# Altium schematic sheet User_Interface.SchDoc("User Interface")
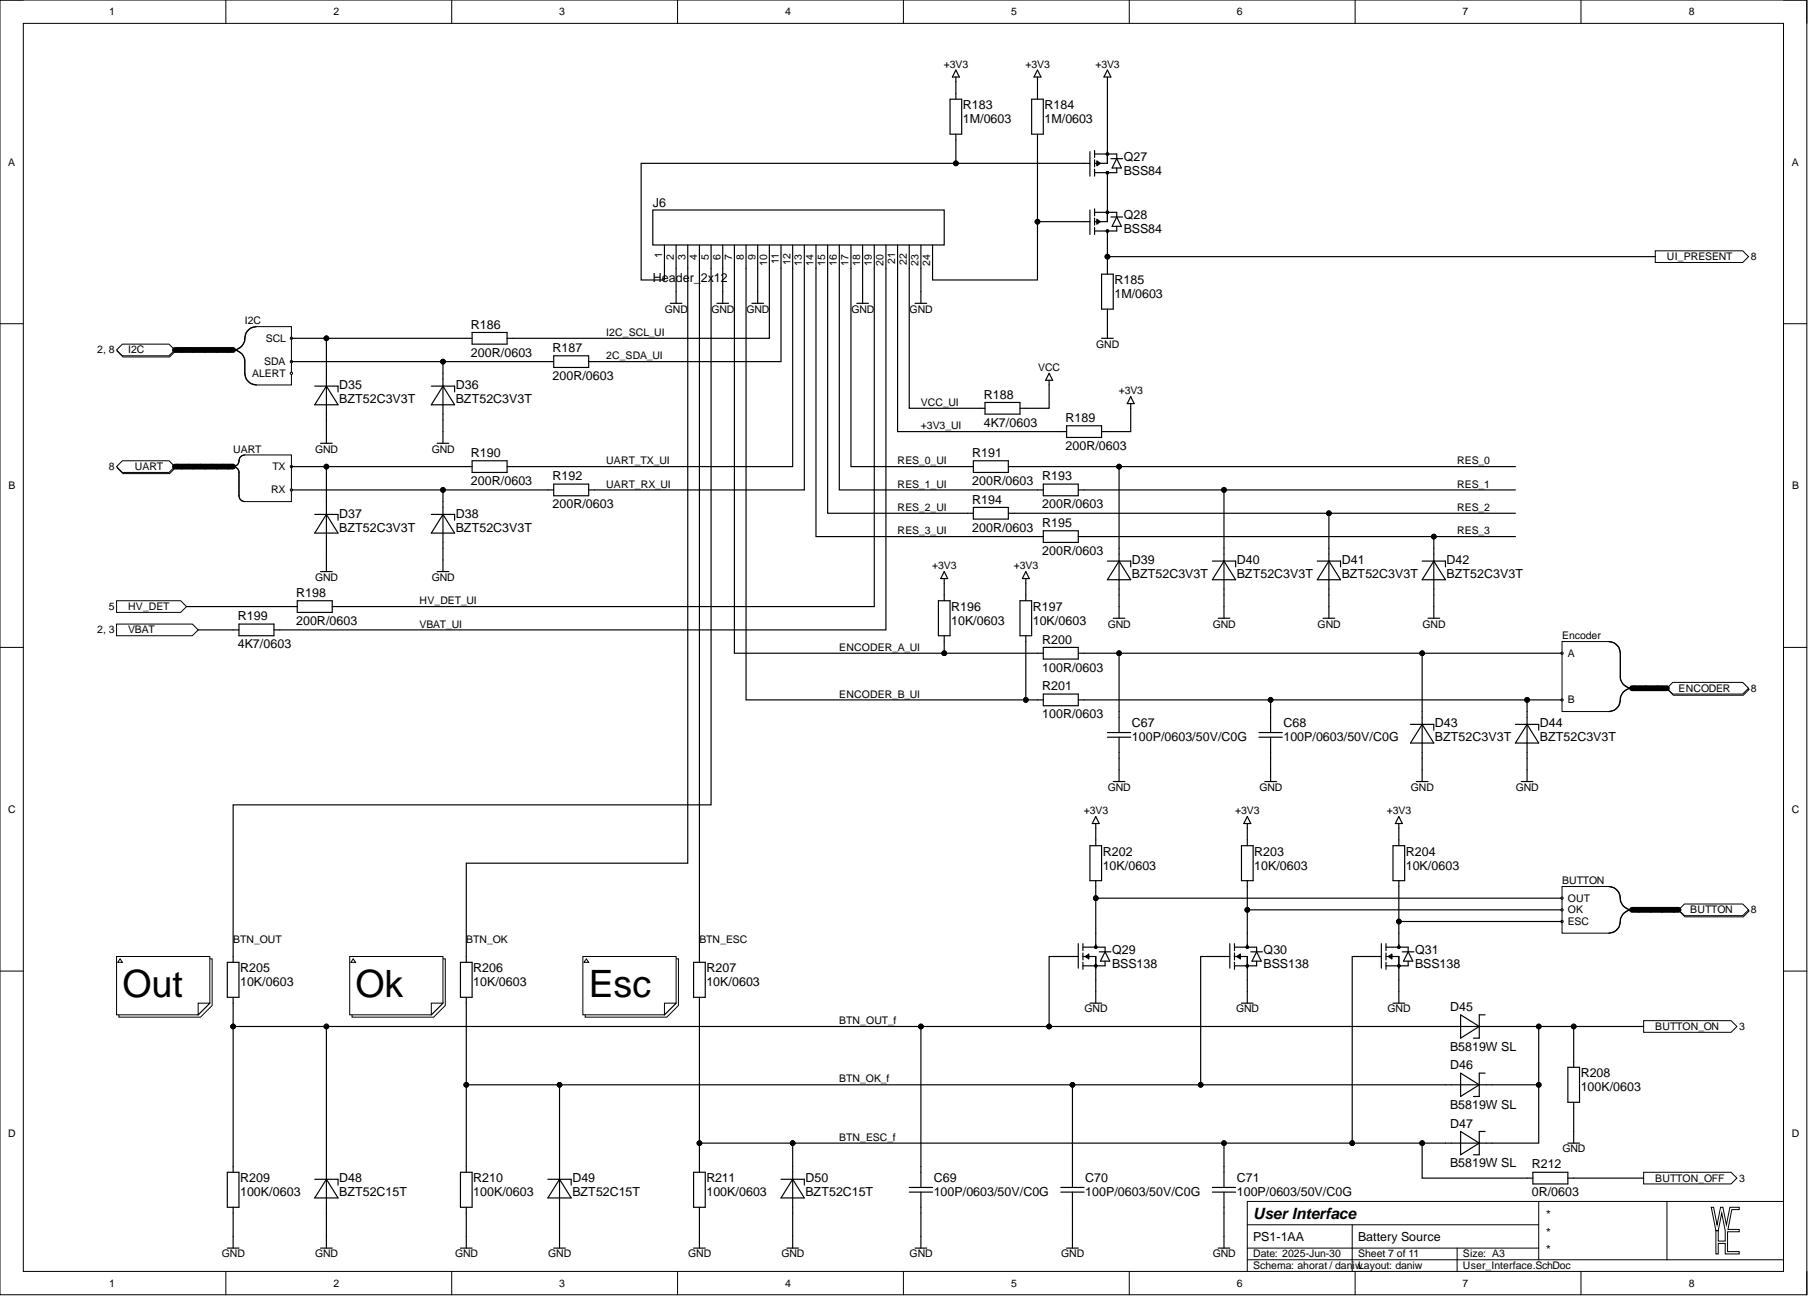
[diagram p0: User_Interface.SchDoc]

## Parts
C67  100P/0603/50V/C0G  [CAP0603_C0G]
C68  100P/0603/50V/C0G  [CAP0603_C0G]
C69  100P/0603/50V/C0G  [CAP0603_C0G]
C70  100P/0603/50V/C0G  [CAP0603_C0G]
C71  100P/0603/50V/C0G  [CAP0603_C0G]
D35  BZT52C3V3T  [SOD1608X065]
D36  BZT52C3V3T  [SOD1608X065]
D37  BZT52C3V3T  [SOD1608X065]
D38  BZT52C3V3T  [SOD1608X065]
D39  BZT52C3V3T  [SOD1608X065]
D40  BZT52C3V3T  [SOD1608X065]
D41  BZT52C3V3T  [SOD1608X065]
D42  BZT52C3V3T  [SOD1608X065]
D43  BZT52C3V3T  [SOD1608X065]
D44  BZT52C3V3T  [SOD1608X065]
D45  B5819W SL  [SOD3718X125]
D46  B5819W SL  [SOD3718X125]
D47  B5819W SL  [SOD3718X125]
D48  BZT52C15T  [SOD1608X065]
D49  BZT52C15T  [SOD1608X065]
D50  BZT52C15T  [SOD1608X065]
J6  Header_2x12  [HEAD_254_2X12]
Q27  BSS84  [SOT2395P280X125-3-SE]
Q28  BSS84  [SOT2395P280X125-3-SE]
Q29  BSS138  [SOT2395P280X125-3-SE]
Q30  BSS138  [SOT2395P280X125-3-SE]
Q31  BSS138  [SOT2395P280X125-3-SE]
R183  1M/0603  [R0603-0.40-WW]
R184  1M/0603  [R0603-0.40-WW]
R185  1M/0603  [R0603-0.40-WW]
R186  200R/0603  [R0603-0.40-WW]
R187  200R/0603  [R0603-0.40-WW]
R188  4K7/0603  [R0603-0.40-WW]
R189  200R/0603  [R0603-0.40-WW]
R190  200R/0603  [R0603-0.40-WW]
R191  200R/0603  [R0603-0.40-WW]
R192  200R/0603  [R0603-0.40-WW]
R193  200R/0603  [R0603-0.40-WW]
R194  200R/0603  [R0603-0.40-WW]
R195  200R/0603  [R0603-0.40-WW]
R196  10K/0603  [R0603-0.40-WW]
R197  10K/0603  [R0603-0.40-WW]
R198  200R/0603  [R0603-0.40-WW]
R199  4K7/0603  [R0603-0.40-WW]
R200  100R/0603  [R0603-0.40-WW]
R201  100R/0603  [R0603-0.40-WW]
R202  10K/0603  [R0603-0.40-WW]
R203  10K/0603  [R0603-0.40-WW]
R204  10K/0603  [R0603-0.40-WW]
R205  10K/0603  [R0603-0.40-WW]
R206  10K/0603  [R0603-0.40-WW]
R207  10K/0603  [R0603-0.40-WW]
R208  100K/0603  [R0603-0.40-WW]
R209  100K/0603  [R0603-0.40-WW]
R210  100K/0603  [R0603-0.40-WW]
R211  100K/0603  [R0603-0.40-WW]
R212  0R/0603  [R0603-0.40-WW]

## Ports
BUTTON, BUTTON.ESC, BUTTON.OK, BUTTON.OUT, BUTTON_OFF, BUTTON_ON, ENCODER, ENCODER.A, ENCODER.B, HV_DET, I2C, I2C.ALERT, I2C.SCL, I2C.SDA, UART, UART.RX, UART.TX, UI_PRESENT, VBAT

## Nets
+3V3: Q27.2 R183.1 R184.1 R189.2 R196.1 R197.1 R202.1 R203.1 R204.1
GND: C67.2 C68.2 C69.2 C70.2 C71.2 D35.2 D36.2 D37.2 D38.2 D39.2 D40.2 D41.2 D42.2 D43.2 D44.2 D48.2 D49.2 D50.2 J6.2 J6.6 J6.9 J6.18 J6.23 Q29.2 Q30.2 Q31.2 R185.2 R208.2 R209.2 R210.2 R211.2
VCC: R188.2
2C_SDA_UI: J6.11 R187.2
+3V3_UI: J6.21 R189.1
BTN_ESC: J6.4 R207.1
BTN_ESC_f: C71.1 D47.2 D50.1 Q31.1 R207.2 R211.1 R212.1
BTN_OK: J6.3 R206.1
BTN_OK_f: C70.1 D46.2 D49.1 Q30.1 R206.2 R210.1
BTN_OUT: J6.5 R205.1
BTN_OUT_f: C69.1 D45.2 D48.1 Q29.1 R205.2 R209.1
BUTTON_OFF: R212.2 ; port BUTTON_OFF
BUTTON_ON: D45.1 D46.1 D47.1 R208.1 ; port BUTTON_ON
ENCODER_A_UI: J6.7 R196.2 R200.1
ENCODER_B_UI: J6.8 R197.2 R201.1
HV_DET: R198.1 ; port HV_DET
HV_DET_UI: J6.19 R198.2
I2C_SCL_UI: J6.10 R186.2
RES_0: D39.1 R191.2
RES_0_UI: J6.17 R191.1
RES_1: D40.1 R193.2
RES_1_UI: J6.16 R193.1
RES_2: D41.1 R194.2
RES_2_UI: J6.15 R194.1
RES_3: D42.1 R195.2
RES_3_UI: J6.14 R195.1
UART_RX_UI: J6.13 R192.2
UART_TX_UI: J6.12 R190.2
UI_PRESENT: Q28.3 R185.1 ; port UI_PRESENT
VBAT: R199.1 ; port VBAT
VBAT_UI: J6.20 R199.2
VCC_UI: J6.22 R188.1
NetC67_1: C67.1 D43.1 R200.2 ; port ENCODER
NetC68_1: C68.1 D44.1 R201.2 ; port ENCODER
NetD35_1: D35.1 R186.1 ; port I2C
NetD36_1: D36.1 R187.1 ; port I2C
NetD37_1: D37.1 R190.1 ; port UART
NetD38_1: D38.1 R192.1 ; port UART
NetJ6_1: J6.1 Q27.1 R183.2
NetJ6_24: J6.24 Q28.1 R184.2
NetQ27_3: Q27.3 Q28.2
NetQ29_3: Q29.3 R202.2 ; port BUTTON
NetQ30_3: Q30.3 R203.2 ; port BUTTON
NetQ31_3: Q31.3 R204.2 ; port BUTTON
N00001: ; port I2C

## Library data of the parts
C67-C71 = 100P/0603/50V/C0G: CAP SM 0603 100pF 50V C0G; Manufacturer: GENERIC; Manufacturer 1: YAGEO; Manufacturer Part Number 1: CC0603JRNPO9BN101; Absolute Value: 1E-10; Database Table Name: C$; Eurocircuits GPN: GPC0603101-C0G; Component Kind: Standard; HelpURL: https://www.yageo.com/upload/media/product/productsearch/datasheet/mlcc/UPY-GP_NP0_16V-to-50V_18.pdf; JLC Type: Basic; Manufacturer 2: YAGEO; Manufacturer Part Number 2: CC0603KRNPO9BN101; Manufacturer Part Number 3: CL10C101JB8NNNC; Package: 0603; Part Number: 100P/0603/50V/C0G; Supplier 1: Digi-Key; Supplier 2: Digi-Key; Supplier 3: LCSC; Supplier Part Number 1: 311-1069-1-ND; Supplier Part Number 2: 311-3600-1-ND; Supplier Part Number 3: C14858; Value: 100pF; Library Name: daniw_altium_lib.DbLib
D35-D44 = BZT52C3V3T: DIODE ZENER 3.3V 300MW SOD523; Manufacturer: Diodes Incorporated; Manufacturer Part Number 1: BZT52C3V3T-7; Database Table Name: D$; Absolute Value: 3.3 V; Component Kind: Standard; HelpURL: https://www.diodes.com/assets/Datasheets/ds30502.pdf; Manufacturer 1: Diodes Incorporated; Manufacturer 2: Micro Commercial Co; Manufacturer 3: BORN; Manufacturer Part Number 2: BZT52C3V3T-TP; Manufacturer Part Number 3: BZT52C3V3T; Package: SC-79, SOD-523; Part Number: BZT52C3V3T; Supplier 1: Digikey; Supplier 2: Digikey; Supplier 3: LCSC; Supplier Part Number 1: BZT52C3V3T-7DICT-ND; Supplier Part Number 2: BZT52C3V3T-TPMSCT-ND; Supplier Part Number 3: C20612286; Value: BZT52C3V3T; Library Name: daniw_altium_lib.DbLib
D45-D47 = B5819W SL: 40V 600mV@1A 1A SOD-123 Schottky Barrier Diodes; Manufacturer: GENERIC; Manufacturer 1: SMC Diode Solutions; Database Table Name: D$; Absolute Value: 40V; Component Kind: Standard; HelpURL: https://datasheet.lcsc.com/lcsc/2304140030_Jiangsu-Changjing-Electronics-Technology-Co---Ltd--B5819W-SL_C8598.pdf; JLC Type: Basic; Manufacturer 3: Jiangsu Changjing Electronics Technology Co., Ltd.; Manufacturer Part Number 1: B5819WSL; Manufacturer Part Number 3: B5819W SL; Package: SOD-123; Part Number: B5819W SL; Supplier 1: Digikey; Supplier 3: LCSC; Supplier Part Number 1: 1655-B5819WSLCT-ND; Supplier Part Number 3: C8598; Value: B5819W SL; Library Name: daniw_altium_lib.DbLib
D48-D50 = BZT52C15T: DIODE ZENER 15V 100MW SOD523; Manufacturer: Micro Commercial Co; Manufacturer Part Number 1: BZT52C15T-TP; Database Table Name: D$; Absolute Value: 15 V; Component Kind: Standard; HelpURL: https://www.mccsemi.com/pdf/Products/BZT52C(B)2V4T-BZT52C(B)39T(SOD-523).pdf; Manufacturer 1: Micro Commercial Co; Manufacturer 2: Diodes Incorporated; Manufacturer 3: Diodes Incorporated; Manufacturer Part Number 2: BZT52C15T-7; Manufacturer Part Number 3: BZT52C15T-7; Package: SC-79, SOD-523; Part Number: BZT52C15T; Supplier 1: Digikey; Supplier 2: Digikey; Supplier 3: LCSC; Supplier Part Number 1: BZT52C15T-TPMSCT-ND; Supplier Part Number 2: BZT52C15T-7DICT-ND; Supplier Part Number 3: C460787; Value: BZT52C15T; Library Name: daniw_altium_lib.DbLib
J6 = Header_2x12: Header 100 mil 2x12; Manufacturer: GENERIC; Database Table Name: CON$; System: Connector; Component Kind: Standard; Part Number: Header_2x12; Library Name: daniw_altium_lib.DbLib
Q27 Q28 = BSS84: 50V P-Channel MOSFET; Manufacturer: GENERIC; Manufacturer 1: Good-Ark Semiconductor; Database Table Name: T$; Component Kind: Standard; HelpURL: https://goodarksemi.com/docs/datasheets/mosfets/BSS84.pdf; JLC Type: Extended; Manufacturer 2: LRC; Manufacturer 3: Jiangsu Changjing Electronics Technology Co., Ltd.; Manufacturer Part Number 1: BSS84; Manufacturer Part Number 2: LBSS84LT1G; Manufacturer Part Number 3: BSS84; Package: SOT23; Part Number: BSS84; Supplier 1: Digi-Key; Supplier 2: LCSC; Supplier 3: LCSC; Supplier Part Number 1: 4786-BSS84CT-ND; Supplier Part Number 2: C8492; Supplier Part Number 3: C114481; Library Name: daniw_altium_lib.DbLib
Q29-Q31 = BSS138: N-Kanal 50 V 220mA (Ta) 350mW (Ta) Oberflächenmontage SOT-23; Manufacturer: GENERIC; Manufacturer 1: ANBON SEMICONDUCTOR (INT'L) LIMITED; Database Table Name: T$; Component Kind: Standard; HelpURL: https://mm.digikey.com/Volume0/opasdata/d220001/medias/docus/3037/BSS138.pdf; JLC Type: Extended; Manufacturer 2: FOSAN; Manufacturer 3: FOSAN; Manufacturer Part Number 1: BSS138; Manufacturer Part Number 2: BSS138; Manufacturer Part Number 3: BSS138; Package: SOT23; Part Number: BSS138; Supplier 1: Digi-Key; Supplier 2: LCSC; Supplier 3: LCSC; Supplier Part Number 1: 4530-BSS138CT-ND; Supplier Part Number 2: C2926186; Supplier Part Number 3: C2926186; Library Name: daniw_altium_lib.DbLib
R183-R185 = 1M/0603: RES SM 0603 1M; Manufacturer: GENERIC; Manufacturer 1: YAGEO; Manufacturer Part Number 1: RC0603FR-131ML; Absolute Value: 1000000; Database Table Name: R$; Eurocircuits GPN: GPR06031M; Component Kind: Standard; HelpURL: https://www.yageo.com/upload/media/product/app/datasheet/rchip/pyu-rc_group_51_rohs_l.pdf; JLC Type: Basic; Manufacturer 2: YAGEO; Manufacturer 3: UNI-ROYAL(Uniroyal Elec); Manufacturer Part Number 2: RC0603FR-101ML; Manufacturer Part Number 3: 0603WAF1004T5E; Package: 0603; Part Number: 1M/0603; Supplier 1: Digi-Key; Supplier 2: Digi-Key; Supplier 3: LCSC; Supplier Part Number 1: 13-RC0603FR-131MLCT-ND; Supplier Part Number 2: 13-RC0603FR-101MLCT-ND; Supplier Part Number 3: C22935; Value: 1M; Library Name: daniw_altium_lib.DbLib
R186 R187 R189-R195 R198 = 200R/0603: RES SM 0603 200R; Manufacturer: GENERIC; Manufacturer 1: YAGEO; Manufacturer Part Number 1: RC0603FR-13200RL; Absolute Value: 200; Database Table Name: R$; Eurocircuits GPN: GPR0603200R; Component Kind: Standard; HelpURL: https://www.yageo.com/upload/media/product/app/datasheet/rchip/pyu-rc_group_51_rohs_l.pdf; JLC Type: Basic; Manufacturer 2: YAGEO; Manufacturer 3: UNI-ROYAL(Uniroyal Elec); Manufacturer Part Number 2: RC0603FR-07200RL; Manufacturer Part Number 3: 0603WAF2000T5E; Package: 0603; Part Number: 200R/0603; Supplier 1: Digi-Key; Supplier 2: Digi-Key; Supplier 3: LCSC; Supplier Part Number 1: 13-RC0603FR-13200RLCT-ND; Supplier Part Number 2: 311-200HRCT-ND; Supplier Part Number 3: C8218; Value: 200R; Library Name: daniw_altium_lib.DbLib
R188 R199 = 4K7/0603: RES SM 0603 4K7; Manufacturer: GENERIC; Manufacturer 1: YAGEO; Manufacturer Part Number 1: RC0603FR-134K7L; Absolute Value: 4700; Database Table Name: R$; Eurocircuits GPN: GPR06034K7; Component Kind: Standard; HelpURL: https://www.yageo.com/upload/media/product/app/datasheet/rchip/pyu-rc_group_51_rohs_l.pdf; JLC Type: Basic; Manufacturer 2: YAGEO; Manufacturer 3: UNI-ROYAL(Uniroyal Elec); Manufacturer Part Number 2: RC0603FR-104K7L; Manufacturer Part Number 3: 0603WAF4701T5E; Package: 0603; Part Number: 4K7/0603; Supplier 1: Digi-Key; Supplier 2: Digi-Key; Supplier 3: LCSC; Supplier Part Number 1: 13-RC0603FR-134K7LCT-ND; Supplier Part Number 2: 13-RC0603FR-104K7LCT-ND; Supplier Part Number 3: C23162; Value: 4K7; Library Name: daniw_altium_lib.DbLib
R196 R197 R202-R207 = 10K/0603: RES SM 0603 10K; Manufacturer: GENERIC; Manufacturer 1: YAGEO; Manufacturer Part Number 1: RC0603FR-1310KL; Absolute Value: 10000; Database Table Name: R$; Eurocircuits GPN: GPR060310K; Component Kind: Standard; HelpURL: https://www.yageo.com/upload/media/product/productsearch/datasheet/rchip/PYu-RC_Group_51_RoHS_L_12.pdf; JLC Type: Basic; Manufacturer 2: YAGEO; Manufacturer 3: UNI-ROYAL(Uniroyal Elec); Manufacturer Part Number 2: RC0603FR-1010KL; Manufacturer Part Number 3: 0603WAF1002T5E; Package: 0603; Part Number: 10K/0603; Supplier 1: Digi-Key; Supplier 2: Digi-Key; Supplier 3: LCSC; Supplier Part Number 1: 13-RC0603FR-1310KLCT-ND; Supplier Part Number 2: 13-RC0603FR-1010KLCT-ND; Supplier Part Number 3: C25804; Value: 10K; Library Name: daniw_altium_lib.DbLib
R200 R201 = 100R/0603: RES SM 0603 100R; Manufacturer: GENERIC; Manufacturer 1: YAGEO; Manufacturer Part Number 1: RC0603FR-13100RL; Absolute Value: 100; Database Table Name: R$; Eurocircuits GPN: GPR0603100R; Component Kind: Standard; HelpURL: https://www.yageo.com/upload/media/product/productsearch/datasheet/rchip/PYu-RC_Group_51_RoHS_L_12.pdf; Manufacturer 2: YAGEO; Manufacturer 3: UNI-ROYAL(Uniroyal Elec); Manufacturer Part Number 2: RC0603FR-10100RL; Manufacturer Part Number 3: 0603WAF1000T5E; Package: 0603; Part Number: 100R/0603; Supplier 1: Digi-Key; Supplier 2: Digi-Key; Supplier 3: LCSC; Supplier Part Number 1: 13-RC0603FR-13100RLCT-ND; Supplier Part Number 2: 13-RC0603FR-10100RLCT-ND; Supplier Part Number 3: C22775; Value: 100R; Library Name: daniw_altium_lib.DbLib
R208-R211 = 100K/0603: RES SM 0603 100K; Manufacturer: GENERIC; Manufacturer 1: YAGEO; Manufacturer Part Number 1: RC0603FR-13100KL; Absolute Value: 100000; Database Table Name: R$; Eurocircuits GPN: GPR0603100K; Component Kind: Standard; HelpURL: https://www.yageo.com/upload/media/product/productsearch/datasheet/rchip/PYu-RC_Group_51_RoHS_L_12.pdf; JLC Type: Basic; Manufacturer 2: YAGEO; Manufacturer 3: UNI-ROYAL(Uniroyal Elec); Manufacturer Part Number 2: RC0603FR-10100KL; Manufacturer Part Number 3: 0603WAF1003T5E; Package: 0603; Part Number: 100K/0603; Supplier 1: Digi-Key; Supplier 2: Digi-Key; Supplier 3: LCSC; Supplier Part Number 1: 13-RC0603FR-13100KLCT-ND; Supplier Part Number 2: 13-RC0603FR-10100KLCT-ND; Supplier Part Number 3: C25803; Value: 100K; Library Name: daniw_altium_lib.DbLib
R212 = 0R/0603: RES SM 0603 0R; Manufacturer: GENERIC; Manufacturer 1: YAGEO; Manufacturer Part Number 1: RC0603FR-070RL; Absolute Value: 0; Database Table Name: R$; Eurocircuits GPN: GPR06030R; Component Kind: Standard; HelpURL: https://www.yageo.com/upload/media/product/app/datasheet/rchip/pyu-rc_group_51_rohs_l.pdf; JLC Type: Basic; Manufacturer 2: YAGEO; Manufacturer 3: UNI-ROYAL(Uniroyal Elec); Manufacturer Part Number 2: RC0603FR-130RL; Manufacturer Part Number 3: 0603WAF0000T5E; Package: 0603; Part Number: 0R/0603; Supplier 1: Digi-Key; Supplier 2: Digi-Key; Supplier 3: LCSC; Supplier Part Number 1: 311-0.0HRCT-ND; Supplier Part Number 2: 13-RC0603FR-130RLCT-ND; Supplier Part Number 3: C21189; Value: 0R; Library Name: daniw_altium_lib.DbLib
